# Altium schematic sheet nw7_rev1.3.SchDoc
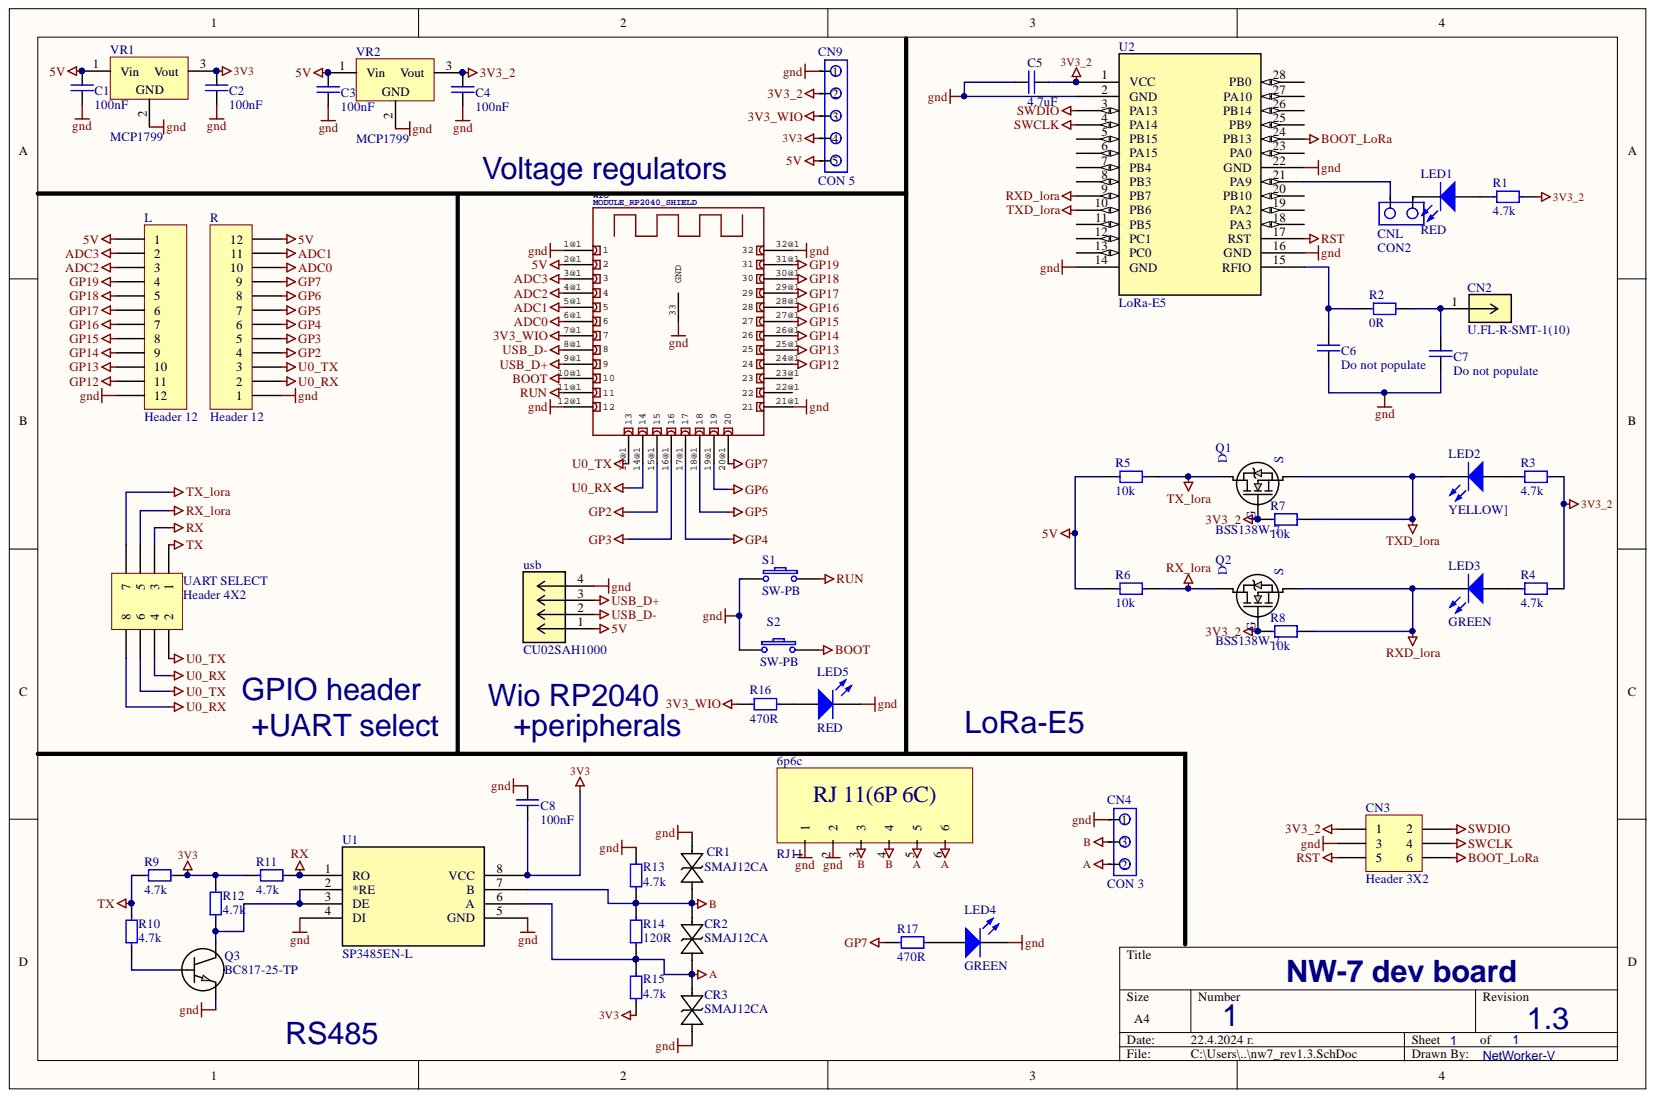
[diagram p0: nw7_rev1.3.SchDoc]

## Parts
6p6c  RJ11  [Rj 11]
C1  100nF  [smd_0603]
C2  100nF  [smd_0603]
C3  100nF  [smd_0603]
C4  100nF  [smd_0603]
C5  4.7uF  [smd_0603]
C6  Do not populate  [smd_0603]
C7  Do not populate  [smd_0603]
C8  100nF  [smd_0603]
CN2  U.FL-R-SMT-1(10)  [CONN_U.FL-R-SMT-1(10)_HIR]
CN3  Header 3X2  [HDR2X3]
CN4  CON 3  [CON HD516V3P]
CN9  CON 5  [JMP 1x5]
CNL  CON2  [JMP 1x2]
CR1  SMAJ12CA  [SMASeries_LTF]
CR2  SMAJ12CA  [SMASeries_LTF]
CR3  SMAJ12CA  [SMASeries_LTF]
L  Header 12  [HDR1X12]
LED1  RED  [smd_0805_led]
LED2  YELLOW]  [smd_0805_led]
LED3  GREEN  [smd_0805_led]
LED4  GREEN  [smd_0805_led]
LED5  RED  [smd_0805_led]
Q1  BSS138W-7  [SOT-323_DIO]
Q2  BSS138W-7  [SOT-323_DIO]
Q3  BC817-25-TP  [BC817_MCC]
R  Header 12  [HDR1X12]
R1  4.7k  [smd_0603]
R2  0R  [smd_0603]
R3  4.7k  [smd_0603]
R4  4.7k  [smd_0603]
R5  10k  [smd_0603]
R6  10k  [smd_0603]
R7  10k  [smd_0603]
R8  10k  [smd_0603]
R9  4.7k  [smd_0603]
R10  4.7k  [smd_0603]
R11  4.7k  [smd_0603]
R12  4.7k  [smd_0603]
R13  4.7k  [smd_0603]
R14  120R  [smd_0603]
R15  4.7k  [smd_0603]
R16  470R  [smd_0603]
R17  470R  [smd_0603]
S1  SW-PB  [sw DTSM6x]
S2  SW-PB  [sw DTSM6x]
U1  SP3485EN-L  [NSOIC8_MXL]
U2  LoRa-E5  [QFN_90687_SEE]
UART_SELECT  Header 4X2  [HDR2X4]
usb  CU02SAH1000  [CONN_CU02SAH1000_CVI]
VR1  MCP1799  [SOT223-3]
VR2  MCP1799  [SOT223-3]
WIO  MODULE_RP2040_SHIELD  [MODULE_RP2040_SHIELD]

## Nets
3V3: C2.2 C8.2 CN9.4 R9.2 R11.1 R12.2 R15.1 U1.8(VCC) VR1.3
3V3_2: C4.2 C5.1 CN3.1 CN9.2 Q1.1 Q2.1 R1.2 R3.2 R4.2 R7.2 R8.2 U2.1(VCC) VR2.3
3V3_WIO: CN9.3 R16.1 WIO.7@1
5V: C1.2 C3.2 CN9.5 L.1 R.12 R5.2 R6.2 usb.1 VR1.1 VR2.1 WIO.2@1
A: 6p6c.5 6p6c.6 CN4.2 CR2.1 CR3.2 R14.1 R15.2 U1.6(A)
ADC0: R.10 WIO.6@1
ADC1: R.11 WIO.5@1
ADC2: L.3 WIO.4@1
ADC3: L.2 WIO.3@1
B: 6p6c.3 6p6c.4 CN4.3 CR1.1 CR2.2 R13.1 R14.2 U1.7(B)
BOOT: S2.2 WIO.10@1
BOOT_LoRa: CN3.6 U2.24(PB13)
gnd: 6p6c.1 6p6c.2 C1.1 C2.1 C3.1 C4.1 C5.2 C6.1 C7.2 C8.1 CN3.3 CN4.1 CN9.1 CR1.2 CR3.1 L.12 LED4.2 LED5.2 Q3.2 R.1 R13.2 S1.1 S2.1 U1.4(DI) U1.5(GND) U2.2(GND) U2.14(GND) U2.16(GND) U2.22(GND) usb.4 VR1.2 VR2.2 WIO.1@1 WIO.12@1 WIO.21@1 WIO.32@1 WIO.33
GP2: R.4 WIO.15@1
GP3: R.5 WIO.16@1
GP4: R.6 WIO.17@1
GP5: R.7 WIO.18@1
GP6: R.8 WIO.19@1
GP7: R.9 R17.2 WIO.20@1
GP12: L.11 WIO.24@1
GP13: L.10 WIO.25@1
GP14: L.9 WIO.26@1
GP15: L.8 WIO.27@1
GP16: L.7 WIO.28@1
GP17: L.6 WIO.29@1
GP18: L.5 WIO.30@1
GP19: L.4 WIO.31@1
RST: CN3.5 U2.17(RST)
RUN: S1.2 WIO.11@1
RX: R11.2 U1.1(RO) UART_SELECT.3
RX_lora: Q2.3 R6.1 UART_SELECT.5
RXD_lora: LED3.2 Q2.2 R8.1 U2.9(PB7)
SWCLK: CN3.4 U2.4(PA14)
SWDIO: CN3.2 U2.3(PA13)
TX: R9.1 R10.2 UART_SELECT.1
TX_lora: Q1.3 R5.1 UART_SELECT.7
TXD_lora: LED2.2 Q1.2 R7.1 U2.10(PB6)
U0_RX: R.2 UART_SELECT.4 UART_SELECT.8 WIO.14@1
U0_TX: R.3 UART_SELECT.2 UART_SELECT.6 WIO.13@1
USB_D+: usb.3 WIO.9@1
USB_D-: usb.2 WIO.8@1
NetC6_2: C6.2 R2.1 U2.15(RFIO)
NetC7_1: C7.1 CN2.1 R2.2
NetCNL_1: CNL.1 LED1.2
NetCNL_2: CNL.2 U2.21(PA9)
NetLED1_1: LED1.1 R1.1
NetLED2_1: LED2.1 R3.1
NetLED3_1: LED3.1 R4.1
NetLED4_1: LED4.1 R17.1
NetLED5_1: LED5.1 R16.2
NetQ3_1: Q3.1 R10.1
NetQ3_3: Q3.3 R12.1 U1.2(*RE) U1.3(DE)

Not connected: U2 5(PB15) 6(PA15) 7(PB4) 8(PB3) 11(PB5) 12(PC1) 13(PC0) 18(PA3) 19(PA2) 20(PB10) 23(PA0) 25(PB9) 26(PB14) 27(PA10) 28(PB0); WIO 22@1 23@1

## Library data of the parts
C1-C8 = Cap: Capacitor; Component Kind: Standard; LatestRevisionDate: 17-Jul-2002; LatestRevisionNote: Re-released for DXP Platform.; PackageDescription: Radial Cap, Thru-Hole; 2 Leads; 0.3 in Pin Spacing; PackageReference: RAD-0.3; Published: 8-Jun-2000; Library Name: SVV Library integrated.IntLib; Publisher: Altium Limited
CN2 = U.FL-R-SMT-1(10): No Description Available; Mfr_Name: Hirose; Component Kind: Standard; Manufacturer_Part_Number: U.FL-R-SMT-1(10); RefDes: RefDes; Type: DEV; Copyright: Copyright (C) 2023 Ultra Librarian. All rights reserved.; Library Name: UPFL-R-SMT-1_10_.IntLib
CN3 = Header 3X2: Header, 3-Pin, Dual row; Component Kind: Standard; LatestRevisionDate: 17-Jul-2002; LatestRevisionNote: Re-released for DXP Platform.; Library Name: Miscellaneous Connectors.IntLib; Signal Integrity: Connector; Publisher: Altium Limited
CNL = CON 2: Component Kind: Standard; Library Name: SVV Library integrated.IntLib
CR1-CR3 = SMAJ12CA: No Description Available; Mfr_Name: Littelfuse Inc; Type: DEV; Component Kind: Standard; Library Name: SMAJ12CA.IntLib; Manufacturer_Part_Number: SMAJ12CA; RefDes: RefDes; Copyright: Copyright (C) 2022 Ultra Librarian. All rights reserved.
L R = Header 12: Header, 12-Pin; Component Kind: Standard; LatestRevisionDate: 17-Jul-2002; LatestRevisionNote: Re-released for DXP Platform.; Library Name: Miscellaneous Connectors.IntLib; Signal Integrity: Connector; Publisher: Altium Limited
LED1-LED5 = LED: Typical INFRARED GaAs LED; Component Kind: Standard; LatestRevisionDate: 08-Jul-2005; LatestRevisionNote: Mech Layer 1 removed.; PackageDescription: LED; 2 Leads; PackageReference: LED-0; Published: 8-Jun-2000; Publisher: Altium Limited
  LED1-LED3: Library Name: SVV Library integrated.IntLib
  LED4 LED5: Library Name: SVV Library integrated2.IntLib
Q1 Q2 = BSS137W-7: Component Kind: Standard; Library Name: BSS138W-7-F.IntLib
Q3 = BC817-25-TP: No Description Available; Mfr_Name: MCC; Type: DEV; Component Kind: Standard; Library Name: BC817-25-TP.IntLib; Manufacturer_Part_Number: BC817-25-TP; RefDes: RefDes; Copyright: Copyright (C) 2023 Ultra Librarian. All rights reserved.
R1-R17 = Res_2: Component Kind: Standard; Library Name: SVV Library integrated.IntLib
S1 S2 = SW-PB: Switch; Component Kind: Standard; LatestRevisionDate: 17-Jul-2002; LatestRevisionNote: Re-released for DXP Platform.; PackageDescription: Switch; 2 Leads; PackageReference: SPST-2; Published: 24-Mar-1999; Library Name: SVV Library integrated.IntLib; Publisher: Altium Limited
U1 = SP3485EN-L: No Description Available; Mfr_Name: MaxLinear; Component Kind: Standard; Library Name: SP3485EN-L.IntLib; Manufacturer_Part_Number: SP3485EN-L; RefDes: RefDes; Type: DEV; Copyright: Copyright (C) 2022 Ultra Librarian. All rights reserved.
U2 = 317990687: No Description Available; Mfr_Name: BeagleBoard; Component Kind: Standard; Library Name: 317990687.IntLib; Manufacturer_Part_Number: 317990687; RefDes: RefDes; Type: DEV; Copyright: Copyright (C) 2023 Ultra Librarian. All rights reserved.
UART_SELECT = Header 4X2: Header, 4-Pin, Dual row; Component Kind: Standard; LatestRevisionDate: 17-Jul-2002; LatestRevisionNote: Re-released for DXP Platform.; Library Name: Miscellaneous Connectors.IntLib; Signal Integrity: Connector; Publisher: Altium Limited
usb = CU02SAH1000: No Description Available; Mfr_Name: CviLux; Component Kind: Standard; Library Name: CU02SAH1000.IntLib; RefDes: RefDes; Type: USB, DVI, HDMI, Connectors
VR1 VR2 = Volt Reg 3: Voltage Regulator; Component Kind: Standard; LatestRevisionDate: 29-May-2009; LatestRevisionNote: IPC-7351 Footprint Added.; PackageDescription: 3-Pin Transistor Outline Package 2.54 mm Pitch, 15.1 mm Lead Span; PackageReference: D2PAK; Published: 24-Mar-1999; Library Name: SVV Library integrated.IntLib; Publisher: Altium Limited
WIO = MODULE_RP2040_SHIELD: GateName_1: G$1; Component Kind: Standard; DeviceSetName: MODULE_RP2040_SHIELD; Library Name: lib rp2040.IntLib; LibraryName: WIO_RP2040_MODULE; Package: MODULE_RP2040_SHIELD; SymbolName_1: MODULE_RP2040_SHIELD
6p6c = RJ11: Component Kind: Standard; Library Name: SVV Library integrated2.IntLib
CN4 = CON 3: Component Kind: Standard; Library Name: SVV Library integrated2.IntLib
CN9 = CON 5: Component Kind: Standard; Library Name: SVV Library integrated.IntLib
